# Altium schematic sheet POWER.SchDoc(POWER)
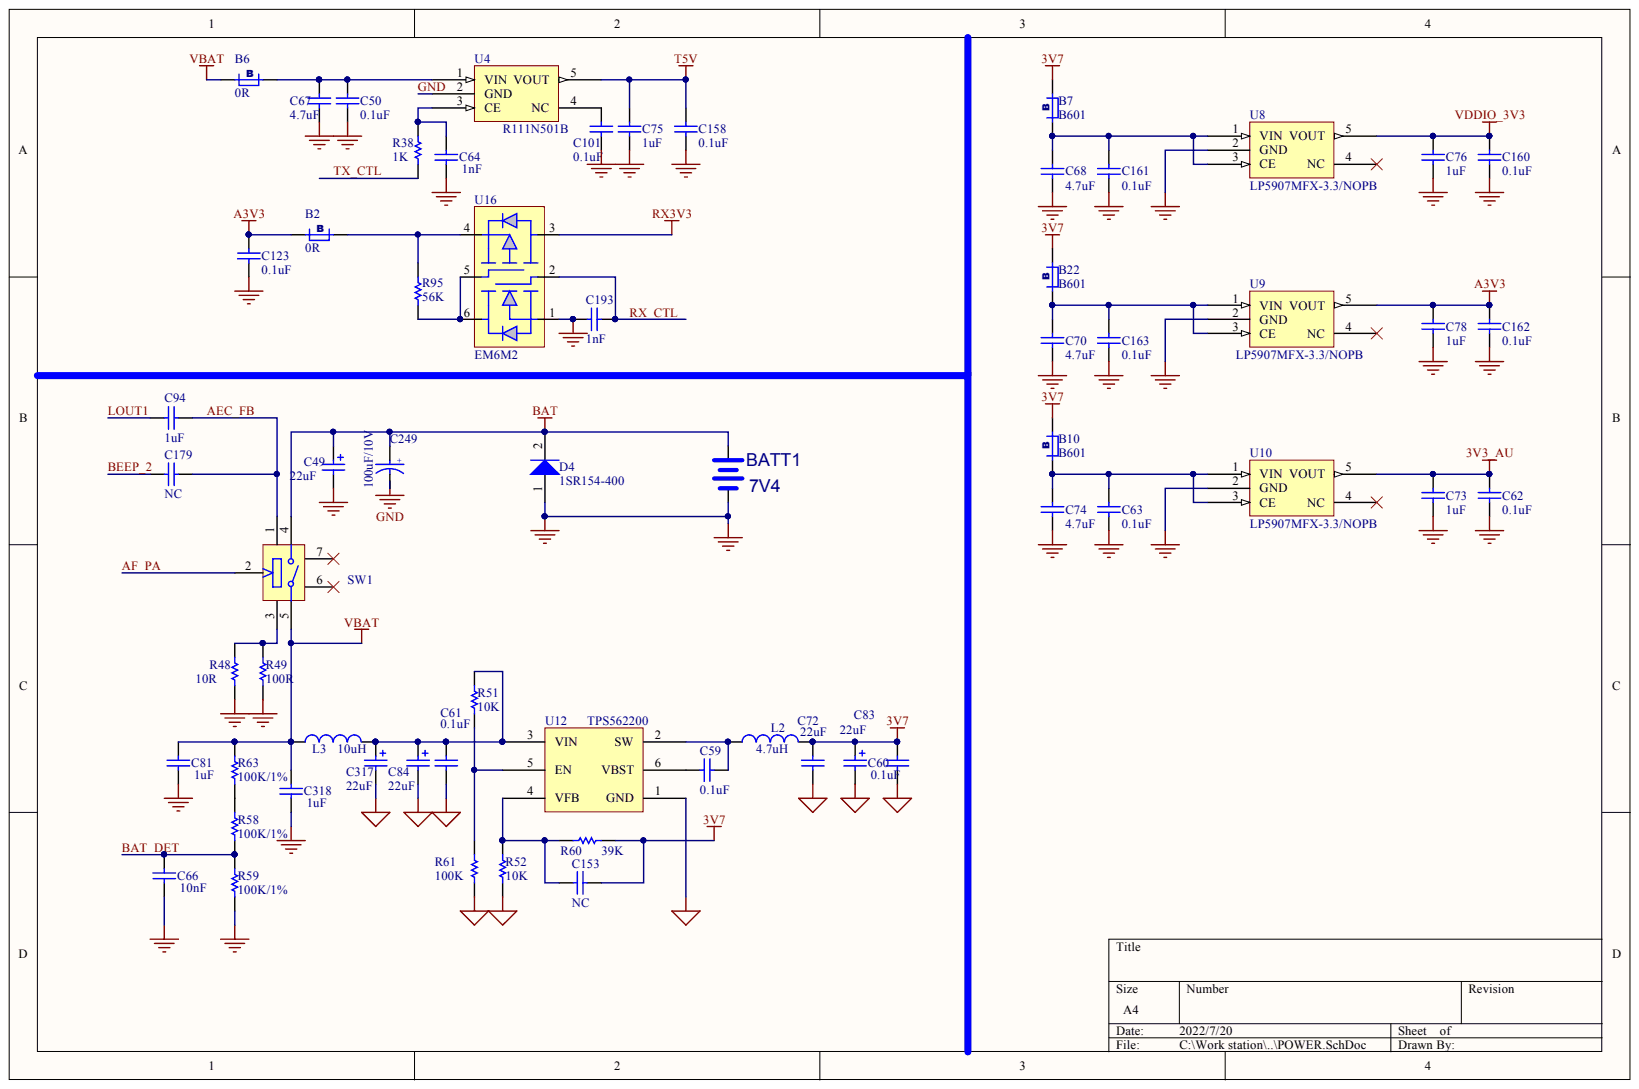
[diagram p0: POWER.SchDoc]

## Parts
B2  0R  [0402]
B6  0R  [0402]
B7  B601  [0402]
B10  B601  [0402]
B22  B601  [0402]
BATT1  7V4  [BATT-JT268_Jun]
C49  22uF  [c0805]
C50  0.1uF  [0402]
C59  0.1uF  [0402]
C60  0.1uF  [0402]
C61  0.1uF  [0402]
C62  0.1uF  [0402]
C63  0.1uF  [0402]
C64  1nF  [0402]
C66  10nF  [0402]
C67  4.7uF  [0402]
C68  4.7uF  [0402]
C70  4.7uF  [0402]
C72  22uF  [0805]
C73  1uF  [0402]
C74  4.7uF  [0402]
C75  1uF  [0402]
C76  1uF  [0402]
C78  1uF  [0402]
C81  1uF  [0402]
C83  22uF  [0805]
C84  22uF  [0805]
C94  1uF  [0402]
C101  0.1uF  [0402]
C123  0.1uF  [0402]
C153  NC  [0402]
C158  0.1uF  [0402]
C160  0.1uF  [0402]
C161  0.1uF  [0402]
C162  0.1uF  [0402]
C163  0.1uF  [0402]
C179  NC  [0402]
C193  1nF  [0402]
C249  100uF/10V  [CD_4X8]
C317  22uF  [0805]
C318  1uF  [0402]
D4  1SR154-400  [1SR154]
L2  4.7uH  [IND_2811]
L3  10uH  [0805]
R38  1K  [0402]
R48  10R  [0402]
R49  100R  [0402]
R51  10K  [0402]
R52  10K  [0402]
R58  100K/1%  [0402]
R59  100K/1%  [0402]
R60  39K  [0402]
R61  100K  [0402]
R63  100K/1%  [0402]
R95  56K  [0402]
SW1  VOL HTR  [VOL HTR_jun]  (value not drawn)
U4  R111N501B  [SOT-25]
U8  LP5907MFX-3.3/NOPB  [SOT-25]
U9  LP5907MFX-3.3/NOPB  [SOT-25]
U10  LP5907MFX-3.3/NOPB  [SOT-25]
U12  TPS562200  [SOT23-6]
U16  EM6M2  [EM6M2]

## Nets
3V3_AU: C62.2 C73.2 U10.5(VOUT)
3V7: B7.2 B10.2 B22.2 C60.1 C72.1 C83.1 C153.1 L2.2 R60.2
A3V3: B2.1 C78.2 C123.2 C162.2 U9.5(VOUT)
BAT: BATT1.1 C49.1 C249.1 D4.2 SW1.4
RX3V3: U16.3
T5V: C75.2 C158.2 U4.5(VOUT)
VBAT: B6.1 C81.1 C318.1 L3.1 R63.1 SW1.5
VDDIO_3V3: C76.2 C160.2 U8.5(VOUT)
AEC_FB: C94.2 C179.2 SW1.1
AF_PA: SW1.2
BAT_DET: C66.1 R58.2 R59.1
BEEP_2: C179.1
GND: BATT1.2 C49.2 C50.1 C60.2 C61.2 C62.1 C63.1 C64.2 C66.2 C67.1 C68.1 C70.1 C72.2 C73.1 C74.1 C75.1 C76.1 C78.1 C81.2 C83.2 C84.2 C101.1 C123.1 C158.1 C160.1 C161.1 C162.1 C163.1 C193.1 C249.2 C317.2 C318.2 D4.1 R48.2 R49.2 R52.2 R59.2 R61.1 U4.2(GND) U8.2(GND) U9.2(GND) U10.2(GND) U12.1(GND) U16.1
LOUT1: C94.1
RX_CTL: C193.2 U16.2
TX_CTL: R38.1
NetB2_2: B2.2 R95.1 U16.4
NetB6_2: B6.2 C50.2 C67.2 U4.1(VIN)
NetB7_1: B7.1 C68.2 C161.2 U8.1(VIN) U8.3(CE)
NetB10_1: B10.1 C63.2 C74.2 U10.1(VIN) U10.3(CE)
NetB22_1: B22.1 C70.2 C163.2 U9.1(VIN) U9.3(CE)
NetC59_1: C59.1 U12.6(VBST)
NetC59_2: C59.2 L2.1 U12.2(SW)
NetC61_1: C61.1 C84.1 C317.1 L3.2 R51.2 U12.3(VIN)
NetC64_1: C64.1 R38.2 U4.3(CE)
NetC101_2: C101.2 U4.4(NC)
NetC153_2: C153.2 R52.1 R60.1 U12.4(VFB)
NetR48_1: R48.1 R49.1 SW1.3
NetR51_1: R51.1 R61.2 U12.5(EN)
NetR58_1: R58.1 R63.2
NetR95_2: R95.2 U16.5 U16.6

Not connected: SW1 6 7; U8 4(NC); U9 4(NC); U10 4(NC)

## Library data of the parts
B2 B6 B7 B10 B22 = B: Component Kind: Standard; Library Name: SCH Lib.SCHLIB; Revision: July-2002: Re-released for DXP Platform.
BATT1 = BATT: Component Kind: Standard
C49 C83 C84 C317 = Cap Pol3: Polarized Capacitor (Surface Mount); Manufacturer: Generic Components; Class: Passive; Value: 100pF; Component Kind: Standard; Library Name: Miscellaneous Devices.IntLib; Published: 08/06/2000; Signal Integrity: Cap Pol; Simulation: CAP; SubClass: Capacitor; Publisher: Altium Hobart Technology Centre
C50 C62 C63 C67 C68 C70 C73-C76 C78 C101 C123 C153 C158 C160-C163 = Cap Semi: Capacitor (Semiconductor SIM Model); Manufacturer: Generic Components; Class: Passive; Value: 100pF; Component Kind: Standard; Library Name: Miscellaneous Devices.IntLib; Published: 08/06/2000; Signal Integrity: Cap Semi; Simulation: CAP; SubClass: Capacitor; Publisher: Altium Hobart Technology Centre
C59-C61 = Cap Semi: Capacitor (Semiconductor SIM Model); Component Kind: Standard; Library Name: Miscellaneous Devices.IntLib; Published: 8-Jun-2000; Revision: July-2002: Re-released for DXP Platform.; Signal Integrity: Cap Semi; Simulation: CAP; Value: 0.1uF; Publisher: Altium Limited
C64 C66 C72 C81 C94 C179 C193 C318 = Cap Semi: Capacitor (Semiconductor SIM Model); Value: 0.1uF; Component Kind: Standard; Library Name: Miscellaneous Devices.IntLib; Published: 8-Jun-2000; Revision: July-2002: Re-released for DXP Platform.; Signal Integrity: Cap Semi; Simulation: CAP; Publisher: Altium Limited
C249 = CAP_1210: Component Kind: Standard; Library Name: zySCHLIB_Z.SCHLIB
D4 = Diode: Default Diode; Manufacturer: Generic Components; Class: Discrete; SubClass: Diode - Switching; Component Kind: Standard; Library Name: Miscellaneous Devices.IntLib; Published: 08/06/2000; Signal Integrity: Diode; Simulation: DIODE; Publisher: Altium Hobart Technology Centre
L2 L3 = Inductor: Manufacturer: Generic Components; Class: Passive; Value: 10mH; Component Kind: Standard; Description: Inductor; Library Name: Miscellaneous Devices.IntLib; Published: 08/06/2000; Signal Integrity: Inductor; Simulation: INDUCTOR; SubClass: Inductor; Publisher: Altium Hobart Technology Centre
R38 = Res Semi: Semiconductor Resistor; Manufacturer: Generic Components; Class: Passive; Component Kind: Standard; Library Name: Miscellaneous Devices.IntLib; Published: 08/06/2000; Signal Integrity: Res Semi; Simulation: RES; SubClass: Resistor; Value: 1K; Publisher: Altium Hobart Technology Centre
R48 R49 R51 R52 R58-R61 R63 R95 = Res Semi: Semiconductor Resistor; Manufacturer: Generic Components; Class: Passive; Value: 1K; Component Kind: Standard; Library Name: Miscellaneous Devices.IntLib; Published: 08/06/2000; Signal Integrity: Res Semi; Simulation: RES; SubClass: Resistor; Publisher: Altium Hobart Technology Centre
SW1 = SWITCH_POWER: Component Kind: Standard; Library Name: SCH Lib.SCHLIB
U4 U8-U10 = LCO_SOT25: LDO SOT25 封装, CE为控制端; Component Kind: Standard; Library Name: SCH Lib.SCHLIB
U12 = TPS562200: Component Kind: Standard; Library Name: SCH Lib.SCHLIB
U16 = EM6M2: Component Kind: Standard; Library Name: SCH Lib.SCHLIB
